# Altium schematic sheet Loadcell.SchDoc(Loadcell)
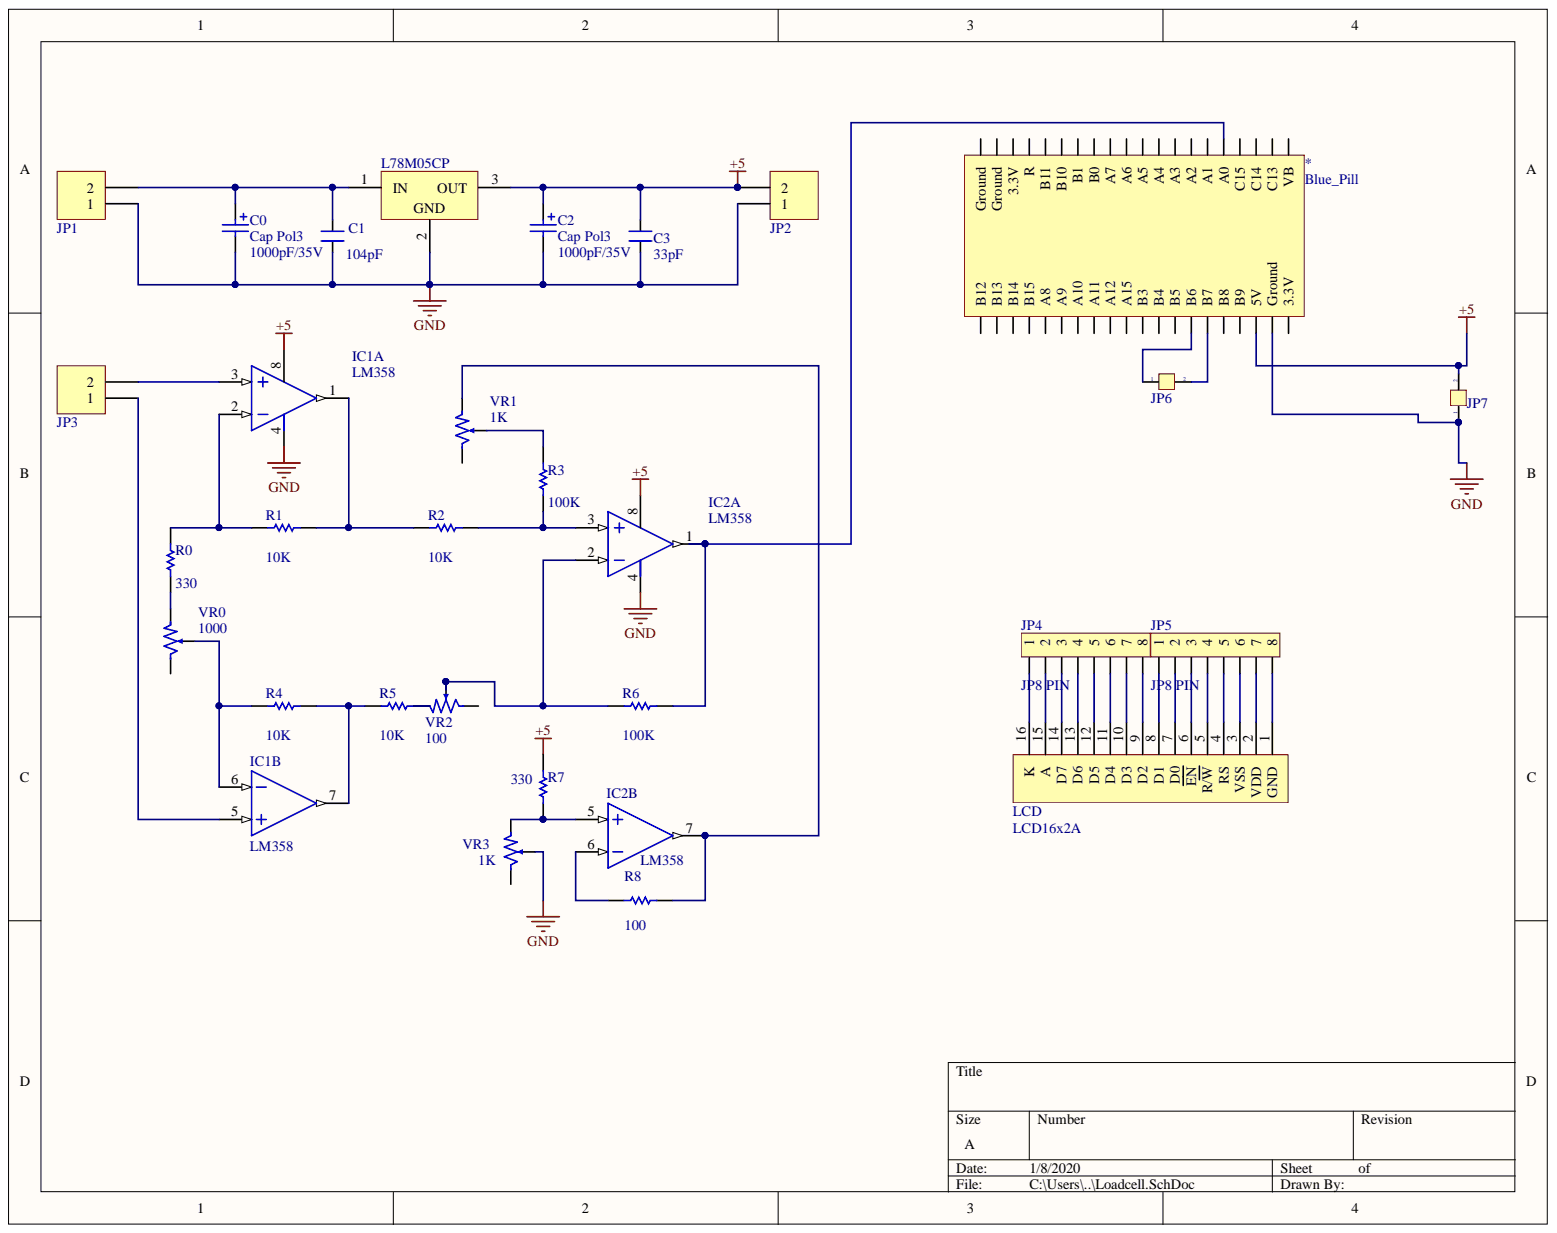
[diagram p0: Loadcell.SchDoc]

## Parts
*  Blue_Pill  [DIP40/W0.6]
C0  Cap Pol3  value 1000pF/35V  [CP2/5]
C1  104pF  [CN1]
C2  Cap Pol3  value 1000pF/35V  [CP2/5]
C3  33pF  [CN1]
IC1  LM358  [DIP8/W0.3]  (parts A,B)
IC2  LM358  [DIP8/W0.3]  (parts A,B)
JP1  Header 2_1  [HED2]  (value not drawn)
JP2  Header 2_1  [HED2]  (value not drawn)
JP3  Header 2_1  [HED2]  (value not drawn)
JP4  JP8 PIN  [JP8]
JP5  JP8 PIN  [JP8]
JP6  Jumper 2  [JP2]  (value not drawn)
JP7  Jumper 2  [JP2]  (value not drawn)
L78M05CP  L78M05CP  [QTO-220H2]
LCD  LCD16x2A  [LCD16X2N]
R0  value 330  [R1/4W]  (hidden comment: Res1)
R1  value 10K  [R1/4W1]  (hidden comment: Res1)
R2  value 10K  [R1/4W]  (hidden comment: Res1)
R3  value 100K  [R1/4W]  (hidden comment: Res1)
R4  value 10K  [R1/4W1]  (hidden comment: Res1)
R5  value 10K  [R1/4W]  (hidden comment: Res1)
R6  value 100K  [R1/4W1]  (hidden comment: Res1)
R7  value 330  [R1/4W]  (hidden comment: Res1)
R8  value 100  [R1/4W1]  (hidden comment: Res1)
VR0  1000  [VR1]
VR1  1K  [VR1]
VR2  100  [VR1]
VR3  1K  [VR1]

## Nets
+5: *.18(5V) C2.1 C3.2 IC1.8 IC2.8 JP2.2 JP7.2 L78M05CP.3 R7.2
GND: *.19(Ground) C0.2 C1.1 C2.2 C3.1 IC1.4 IC2.4 JP1.1 JP2.1 JP7.1 L78M05CP.2 VR3.2
Net*_14: *.14(B6) JP6.1
Net*_15: *.15(B7) JP6.2
Net*_25: *.25(A0) IC2.1 R6.1
NetC0_1: C0.1 C1.2 JP1.2 L78M05CP.1
NetIC1_1: IC1.1 R1.1 R2.2
NetIC1_2: IC1.2 R0.2 R1.2
NetIC1_3: IC1.3 JP3.2
NetIC1_5: IC1.5 JP3.1
NetIC1_6: IC1.6 R4.2 VR0.2
NetIC1_7: IC1.7 R4.1 R5.2
NetIC2_2: IC2.2 R6.2 VR2.2
NetIC2_3: IC2.3 R2.1 R3.1
NetIC2_5: IC2.5 R7.1 VR3.1
NetIC2_6: IC2.6 R8.2
NetIC2_7: IC2.7 R8.1 VR1.1
NetJP4_1: JP4.1 LCD.16(K)
NetJP4_2: JP4.2 LCD.15(A)
NetJP4_3: JP4.3 LCD.14(D7)
NetJP4_4: JP4.4 LCD.13(D6)
NetJP4_5: JP4.5 LCD.12(D5)
NetJP4_6: JP4.6 LCD.11(D4)
NetJP4_7: JP4.7 LCD.10(D3)
NetJP4_8: JP4.8 LCD.9(D2)
NetJP5_1: JP5.1 LCD.8(D1)
NetJP5_2: JP5.2 LCD.7(D0)
NetJP5_3: JP5.3 LCD.6(EN)
NetJP5_4: JP5.4 LCD.5(R/W)
NetJP5_5: JP5.5 LCD.4(RS)
NetJP5_6: JP5.6 LCD.3(VSS)
NetJP5_7: JP5.7 LCD.2(VDD)
NetJP5_8: JP5.8 LCD.1(GND)
NetR0_1: R0.1 VR0.1
NetR3_2: R3.2 VR1.2
NetR5_1: R5.1 VR2.1

Not connected: * 1(B12) 2(B13) 3(B14) 4(B15) 5(A8) 6(A9) 7(A10) 8(A11) 9(A12) 10(A15) 11(B3) 12(B4) 13(B5) 16(B8) 17(B9) 20(3.3V) 21(VB) 22(C13) 23(C14) 24(C15) 26(A1) 27(A2) 28(A3) 29(A4) 30(A5) 31(A6) 32(A7) 33(B0) 34(B1) 35(B10) 36(B11) 37(R) 38(3.3V) 39(Ground) 40(Ground); VR0 3; VR1 3; VR2 3; VR3 3

## Library data of the parts
C0 C2 = Cap Pol3: Polarized Capacitor (Surface Mount); VALUE: C?; PackageReference: C0805; LatestRevisionNote: Re-released for DXP Platform.; LatestRevisionDate: 17-Jul-2002; Component Kind: Standard; Publisher: Altium Limited; Library Name: syhaunguyen.SchLib
C1 = CN0: Cremic For Xtal 1pF => 100pF; Value: 33pF; Component Kind: Standard; Library Name: syhaunguyen.SchLib
C3 = CN0: Cremic For Xtal 1pF => 100pF; Value: 33pF; Component Kind: Standard; Library Name: syhaunguyen.SchLib
IC1 IC2 = LM358: Low-Power Dual Operational Amplifier; Value: LM358; Component Kind: Standard; Library Name: syhaunguyen.SchLib
JP1-JP3 = Header 2_1: Header, 2-Pin; Manufacturer: Generic Components; Class: Connector; Value: Header 2; Component Kind: Standard; Library Name: syhaunguyen.SchLib
JP4 JP5 = JP8: Value: JP7; Description: JP8 PIN; Component Kind: Standard; Library Name: syhaunguyen.SchLib
JP6 JP7 = JP 2: Value: JP 2; Description: Jumper 2; Component Kind: Standard; Library Name: syhaunguyen.SchLib
L78M05CP = L78M05CP: Positive Voltage Regulator; Note: Tab is connected to pin 3 (GND).; Published: 24-Aug-2001; PackageVersion: Jul-1998; PackageReference: ISOWATT220AB; PackageDescription: TO, Thru-Hole, Vertical, Heatsink Mounted; 3 In-Line Leads; Pitch 2.54 mm; Pin sequence 1, 3, 2; LatestRevisionNote: Re-released for DXP Platform.; LatestRevisionDate: 17-Jul-2002; DatasheetVersion: Apr-1994; ComponentLink2URL: http://www.st.com/stonline/products/literature/ds/2146/l78m05c.pdf; ComponentLink2Description: Datasheet; ComponentLink1URL: http://www.st.com/; ComponentLink1Description: Manufacturer Link; Component Kind: Standard; Publisher: Altium Limited; Library Name: syhaunguyen.SchLib
LCD = LCD16x2: LCD dipslay 16 char, 2 line; Value: LCD16x2A; Component Kind: Standard; Library Name: syhaunguyen.SchLib
R0 R2 R3 R5 R7 = Res1_1: Resistor; Manufacturer: Generic Components; Class: Passive; SubClass: Resistor; Simulation: RESISTOR; Signal Integrity: Res1; Published: 08/06/2000; Component Kind: Standard; Publisher: Altium Hobart Technology Centre; Library Name: syhaunguyen.SchLib
R1 R4 R6 R8 = Res1_2: Resistor; Manufacturer: Generic Components; Class: Passive; SubClass: Resistor; Simulation: RESISTOR; Signal Integrity: Res1; Published: 08/06/2000; Component Kind: Standard; Publisher: Altium Hobart Technology Centre; Library Name: syhaunguyen.SchLib
VR0-VR3 = VR1: Var Resistor; Value: VR 10K; Component Kind: Standard; Library Name: syhaunguyen.SchLib
* = Blue_Pill: Library Name: My Library.SchLib; Component Kind: Standard
